# Altium schematic sheet Sensor.SchDoc
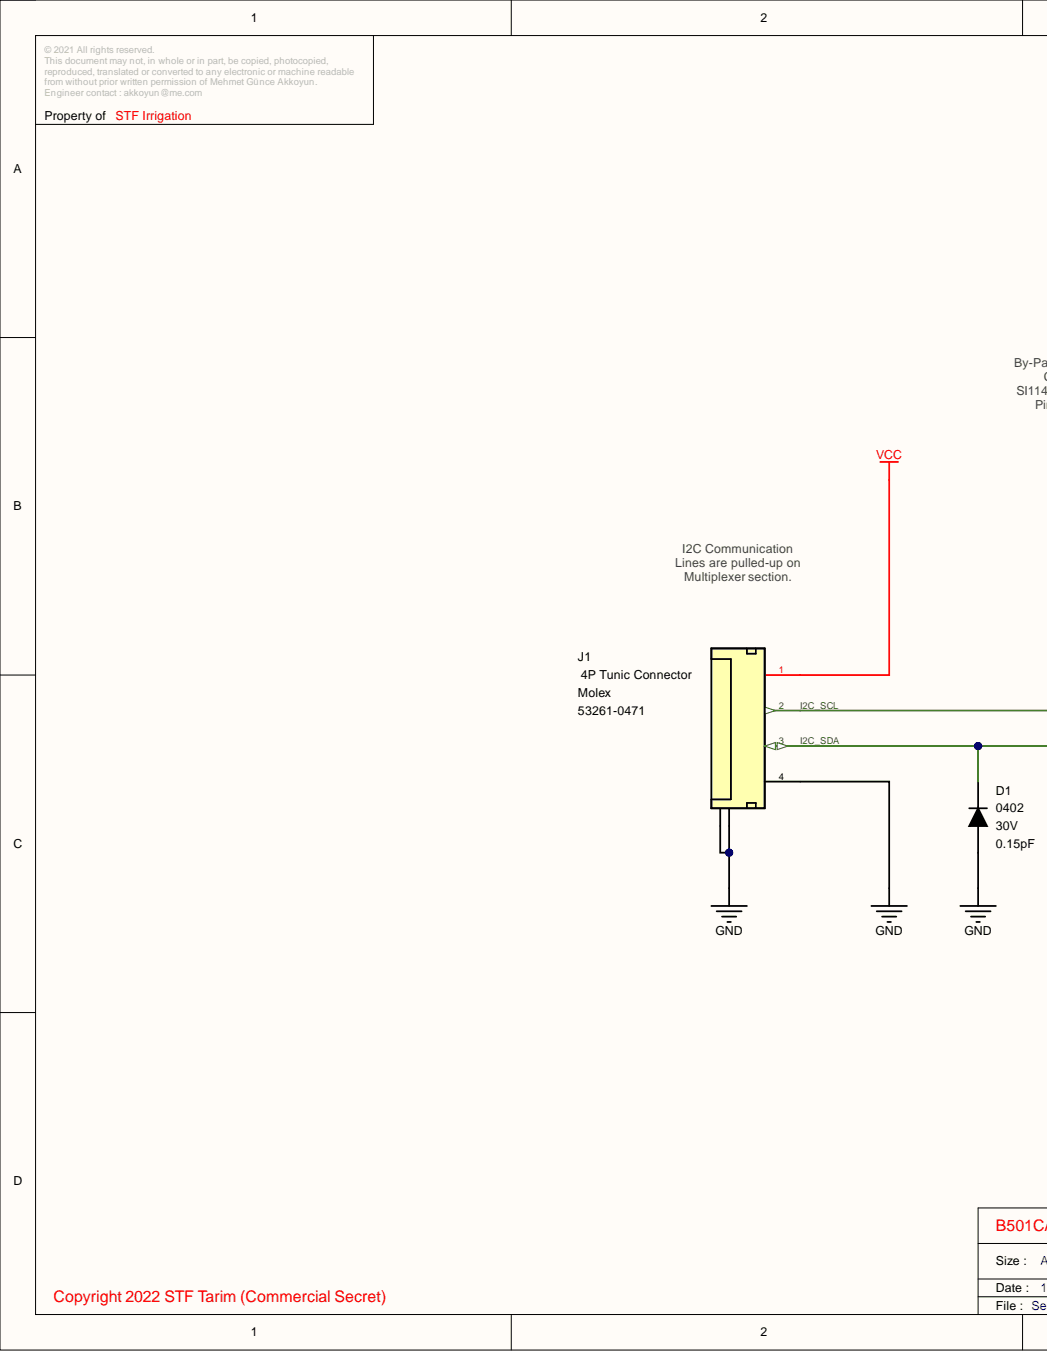
[diagram p0: Sensor.SchDoc, left]
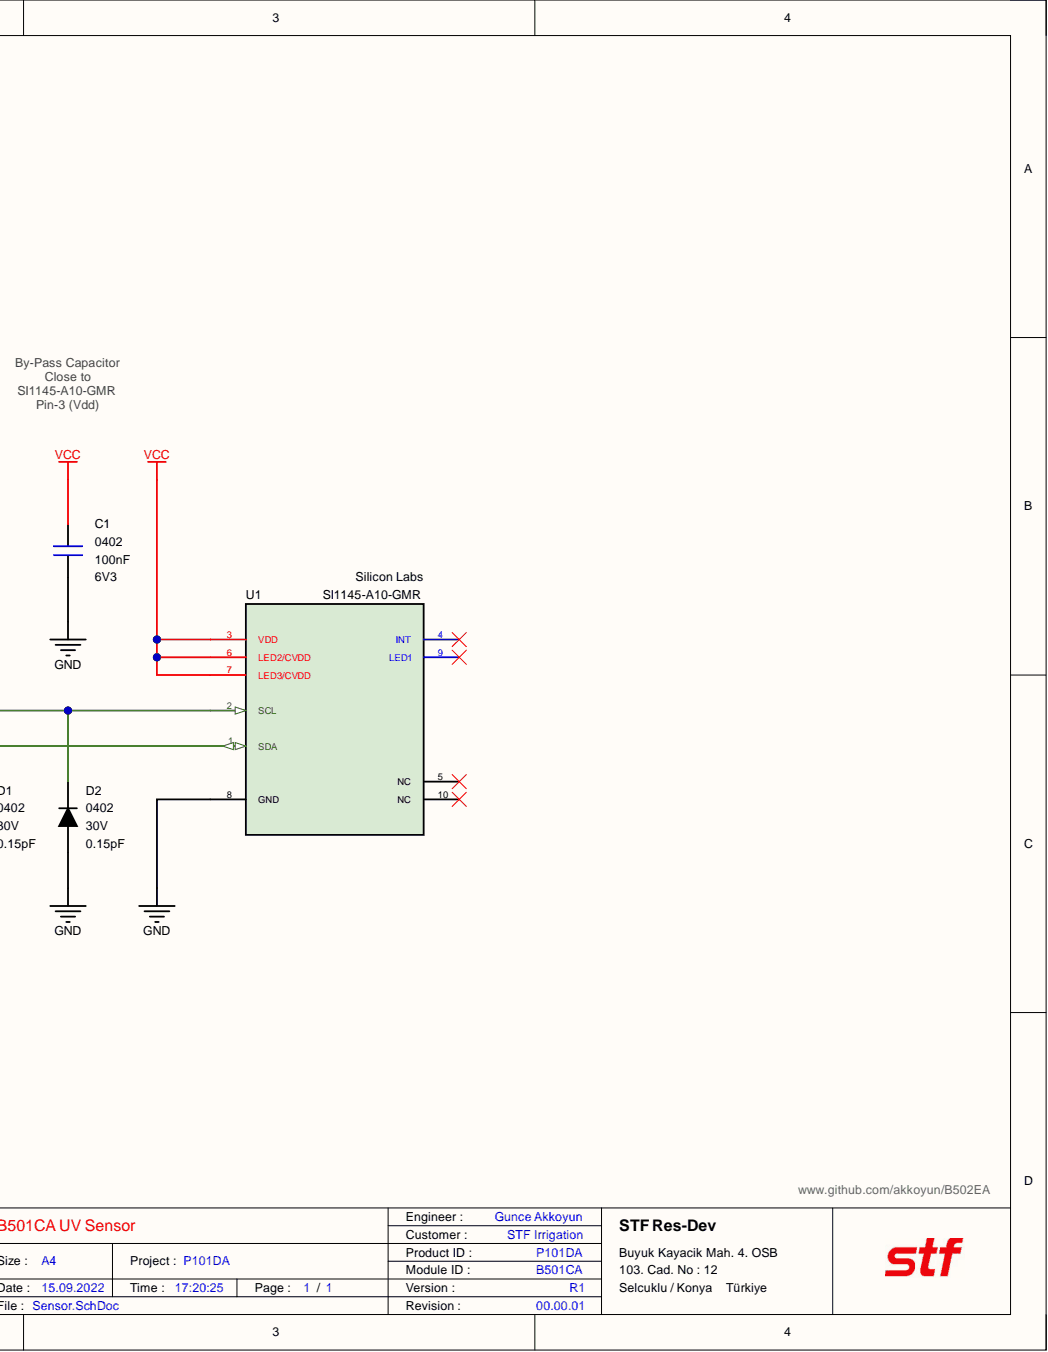
[diagram p1: Sensor.SchDoc, right]

## Parts
C1  value 100nF  Case: 0402  Voltage: 6V3  [CAPACITOR_0402]  (hidden comment: Multilayer Ceramic Capacitor)
D1  Breakdown Voltage: 30V  Capacitance: 0.15pF  Case: 0402  [ESD_0402]  (hidden comment: 0402ESDA-MLP1)
D2  Breakdown Voltage: 30V  Capacitance: 0.15pF  Case: 0402  [ESD_0402]  (hidden comment: 0402ESDA-MLP1)
J1  Manufacturer Name: Molex  Manufacturer Part Number: 53261-0471  (hidden comment: 4P SMD Tunic Connector)
U1  Manufacturer Name: Silicon Labs  Manufacturer Part Number: SI1145-A10-GMR

## Nets
GND: C1.1 D1.2 D2.2 J1.4 J1.5 J1.6 U1.8(GND)
VCC: C1.2 J1.1 U1.3(VDD) U1.6(LED2/CVDD) U1.7(LED3/CVDD)
I2C_SCL: D2.1 J1.2 U1.2(SCL)
I2C_SDA: D1.1 J1.3 U1.1(SDA)

Not connected: U1 4(INT) 5(NC) 9(LED1) 10(NC)

## Library data of the parts
C1 = 100nF [0402-6V3]: Capacitor - 100nF [0402-6V3] (Ceramic); Manufacturer Name: AVX; Manufacturer Part Number: 04026D104KAT4A; Dielectric: X5R; Tolerance: %10; Component Kind: Standard; ComponentLink1Description: Mouser; ComponentLink1URL: https://www.mouser.com.tr/ProductDetail/AVX/04026D104KAT4A?qs=sGAEpiMZZMs0AnBnWHyRQPg4iYkCw7IMED%2F4kpqgf7w%3D; ComponentLink2Description: DataSheet; ComponentLink2URL: https://www.mouser.com.tr/datasheet/2/40/cx5r-776519.pdf; Height: 0.25mm; Library Name: P101DA_Library.IntLib; Maximum Operating Temperature: +85; Minimum Operating Temperature: -55; ROHS: Yes
D1 D2 = TPD1E05U06DPYR: SUPPRESSOR ESD 30VDC 0402 HFREE; Manufacturer Name: Eaton; Component Kind: Standard; Library Name: P101DA_Library.IntLib; Manufacturer Part Number: 0402ESDA-MLP1
J1 = Mini Tunic 4P (1.25): Mini Tunic Connector with 4P; Pitch: 1.25mm; Position: 4; Case: SMD; Component Kind: Standard; ComponentLink1Description: DataSheet; ComponentLink1URL: https://www.mouser.com.tr/datasheet/2/276/0532610471_PCB_HEADERS-170942.pdf; ComponentLink2Description: Mouser; ComponentLink2URL: https://www.mouser.com.tr/ProductDetail/Molex/53261-0471?qs=%2Fha2pyFaduhLhIsk8tywtK7BwWtguBSP4XxyDBTOTfs%3D; Library Name: P101DA_Library.IntLib; ROHS: Yes
U1 = Sensor - Si1145-A10-GMR: Proximity Sensors I2C UV Index and Proximity/Ambient Light Sensor with 1 LED Driver; Case: QFN-10; Component Kind: Standard; Library Name: P101DA_Library.IntLib; RoHS: Yes
